# Altium schematic sheet [2] Connectors.SchDoc("[2] Connectors")
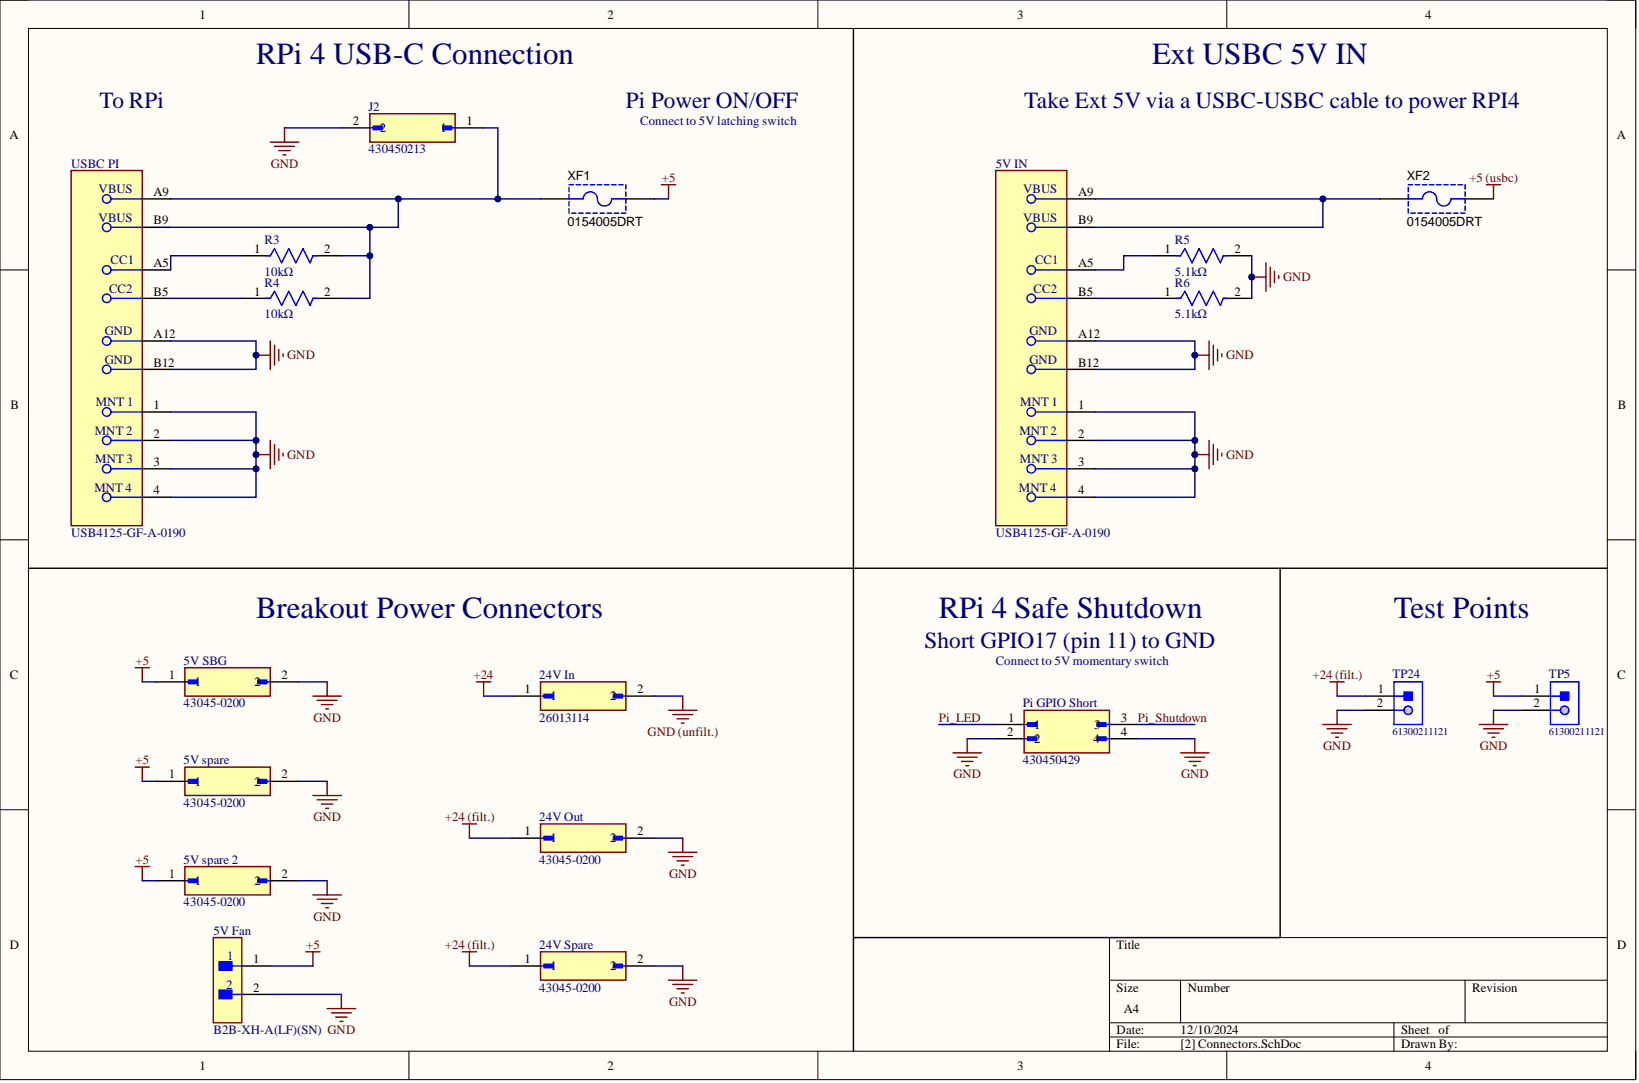
[diagram p0: [2] Connectors.SchDoc]

## Parts
5V_Fan  B2B-XH-A(LF)(SN)
5V_IN  USB4125-GF-A-0190
5V_SBG  43045-0200
5V_spare  43045-0200
5V_spare_2  43045-0200
24V_In  26013114
24V_Out  43045-0200
24V_Spare  43045-0200
J2  430450213
Pi_GPIO_Short  430450429
R3  10kΩ
R4  10kΩ
R5  5.1kΩ
R6  5.1kΩ
TP5  61300211121
TP24  61300211121
USBC_PI  USB4125-GF-A-0190
XF1  0154005DRT
XF2  0154005DRT

## Nets
+5: 5V_Fan.1 5V_SBG.1 5V_spare.1 5V_spare_2.1 TP5.1 XF1.2
+5 (usbc): XF2.2
+24: 24V_In.1
+24 (filt.): 24V_Out.1 24V_Spare.1 TP24.1
GND: 5V_Fan.2 5V_IN.1 5V_IN.2 5V_IN.3 5V_IN.4 5V_IN.A12 5V_IN.B12 5V_SBG.2 5V_spare.2 5V_spare_2.2 24V_Out.2 24V_Spare.2 J2.2 Pi_GPIO_Short.2 Pi_GPIO_Short.4 R5.2 R6.2 TP5.2 TP24.2 USBC_PI.1 USBC_PI.2 USBC_PI.3 USBC_PI.4 USBC_PI.A12 USBC_PI.B12
GND (unfilt.): 24V_In.2
Pi_LED: Pi_GPIO_Short.1
Pi_Shutdown: Pi_GPIO_Short.3
Net5V IN_A5: 5V_IN.A5 R5.1
Net5V IN_A9: 5V_IN.A9 5V_IN.B9 XF2.1
Net5V IN_B5: 5V_IN.B5 R6.1
NetJ2_1: J2.1 R3.2 R4.2 USBC_PI.A9 USBC_PI.B9 XF1.1
NetR3_1: R3.1 USBC_PI.A5
NetR4_1: R4.1 USBC_PI.B5

## Library data of the parts
5V_Fan = CMP-2000-05888-3: CONN HEADER VERT 2POS 2.5MM; Connector Type: Male Pin; Contact Resistance: 20mR; Current Rating: 3A; Insulation Resistance: 1GR; Max Voltage Rating (AC): 250V; Number of Contacts: 2; Wire/Cable Gauge: 22AWG; Color: Natural; Component Kind: Standard; Contact Material: Brass; Contact Plating: Tin; Contact Type: Male Pin; Depth: 5.75mm; Fastening Type: Detent; Housing Color: White; Housing Material: Polyamide; Lead Free: Lead Free; Lead Pitch: 2.5mm; Length: 7.4mm; Library Name: Altium Content Vault; Max Current Rating: 3A; Max Operating Temperature: 85°C; Max Voltage Rating (DC): 250V; Min Operating Temperature: -25°C; Mount: Through Hole; Number of Positions: 2; Number of Rows: 1; Orientation: Straight; Packaging: Bulk; Pitch: 2.5mm; REACH SVHC: No SVHC; Series: XH; Termination: Solder; Voltage Rating: 250V; Voltage Rating (AC): 250V
5V_IN USBC_PI = CMP-00036-1: USB Connector with 1.90mm shell stake length; Component Kind: Standard; Library Name: BumbleBee Autonomous Systems - NUS
5V_SBG 5V_spare 5V_spare_2 24V_Out 24V_Spare = CMP-075-000000-1: Connector Header Through Hole, Right Angle 2 position -; MF: Molex; Check_prices: https://www.snapeda.com/parts/43045-0200/Molex/view-part/?ref=eda; Component Kind: Standard; Component Type: Standard; Design Item ID: 43045-0200; Digi-Key_Part_Number: WM1813-ND; MP: 43045-0200; Package: None; Pin Count: 2; Purchase-URL: https://pricing.snapeda.com/search/part/43045-0200/?ref=eda; SnapEDA_Link: https://www.snapeda.com/parts/43045-0200/Molex/view-part/?ref=snap; Source: 43045-0200.SchLib; Library Name: BumbleBee Autonomous Systems - NUS
24V_In = 26013114: Connector Header Through Hole, Right Angle 2 position; MF: {'manufacturer': u'Molex'}; Availability: Unavailable; Component Kind: Standard; MP: 26013114; Package: None; Price: None
J2 = CMP-087-000000-1: Availability: Unavailable; Component Kind: Standard; Component Type: Standard; Design Item ID: 430450213; MP: 430450213; Package: None; Pin Count: 2; Price: None; Source: 430450213.SchLib; Library Name: BumbleBee Autonomous Systems - NUS
Pi_GPIO_Short = CMP-077-000000-1: Availability: Unavailable; Component Kind: Standard; Component Type: Standard; Design Item ID: 430450429; MP: 430450429; Package: None; Pin Count: 4; Price: None; Source: 430450429.SchLib; Library Name: BumbleBee Autonomous Systems - NUS
R3 R4 = CMP-00003-1: RT0805FRE0710KL Res Thin Film 0805 10K Ohm 1% 0.125W(1/8W) ±50ppm/°C Pad SMD T/R; Max Power Dissipation: 125mW; Value: 10kR; Voltage Rating: 150V; Case Code (Imperial): 0805; Case Code (Metric): 2012; Case/Package: 0805; Component Kind: Standard; Datasheet: https://www.mouser.sg/datasheet/2/447/PYu_RT_1_to_0_01_RoHS_L_12-3003070.pdf; Height: 0.024inch; Lead Free: Lead Free; Length: 0.079inch; Max Operating Temperature: 125°C; Min Operating Temperature: -55°C; Number of Terminations: 2; Package Quantity: 5000; Packaging: Tape and Reel; Power Rating: 125mW; Reach SVHC Compliant: No; Resistance Tolerance: 1%; Resistor Type: Thin Film; RoHS Compliant: Yes; Series: RT; Technology: THIN FILM; Temperature Coefficient: 50ppm/°C; Termination: SMD/SMT; Tolerance: 1%; Width: 1.25mm; Working Voltage: 150V; Library Name: BumbleBee Autonomous Systems - NUS
R5 R6 = CMP-2001-01539-2: RES SMD 5.1K OHM 1% 1/8W 0805; Manufacturer: Yageo; Manufacturer Part Number: RT0805FRE075K1L; Power Rating: 0.125W; Temperature Coefficient: 50 ppm/°C; Tolerance: 0.0001; Voltage Rating: 150V; Automotive: No; Category: Res; Component Kind: Standard; ComponentLink2Description: Datasheet; ComponentLink2URL: http://www.yageo.com/documents/recent/PYu-RT_1-to-0.01_RoHS_L_9.pdf; Composition: Thin Film; Device Class L1: Passive Components; Device Class L2: Resistors; Device Class L3: Chip SMD Resistors; Digikey Description: RES SMD 5.1K OHM 1% 1/8W 0805; Footprint Url: http://www.yageo.com/exep/pages/download/literatures/PYu-R_Mount_10.pdf; Height: 0.6mm; Ipc Land Pattern Name: RESC200125X50; Lead Free: Yes; Library Name: Altium Content Vault; Mouser Description: Res Thin Film 0805 5.1K Ohm 1% 1/8W ±50ppm/°C Molded SMD SMD Paper T/R; Octopart: https://octopart.com/rt0805fre075k1l-yageo-1396245; Package: RT0805; Resistance: 5.1KΩ; RoHS: TRUE; Series: RT; Temperature: -55°C to +155°C; Temperature Range High: +155°C; Temperature Range Low: -55°C; Value: 5.1KΩ
TP5 TP24 = CMP-00045-1: THT Vertical Pin Header WR-PHD, Pitch 2.54 mm, Single Row, 2 pins; Current Rating: 3A; Gender: Male; Number of Contacts: 2; Pitch: 0.1inch; Voltage Rating: 250V; Color: Black; Component Kind: Standard; Contact Gender: Male; Contact Material: Copper Alloy,Copper; Contact Mating Length: 0.236inch; Contact Plating: Gold; Contact Type: Male Pin; Housing Material: PBT; Lead Free: Lead Free; Max Operating Temperature: 125°C; Min Operating Temperature: -40°C; Mounting Technology: Through Hole; Number of Positions: 2; Number of Rows: 1; Packaging: Bulk; Reach SVHC Compliant: Unknown; RoHS Compliant: Yes; Series: WR-PHD; Termination: Through Hole; Library Name: BumbleBee Autonomous Systems - NUS
XF1 XF2 = CMP-00048-1: FUSE BRD MNT 5A 125VAC/VDC 2SMD; Body Material: Thermoplastic; Voltage Rating: 125V; Case/Package: SMD/SMT; Component Kind: Standard; Current Rating: 5A; Flammability Rating: UL94 V-0; Fuse Current: 5A; Height: 3.81mm; Lead Free: Lead Free; Length: 9.73mm; Max Operating Temperature: 125°C; Min Operating Temperature: -55°C; Reach SVHC Compliant: Unknown; Size: 5.03mm; Terminal Material: Copper; Voltage Rating (AC): 125V; Voltage Rating (DC): 125V; Width: 5.03mm; Working Voltage: 125V; Library Name: BumbleBee Autonomous Systems - NUS
